# Altium schematic sheet Connect.SchDoc
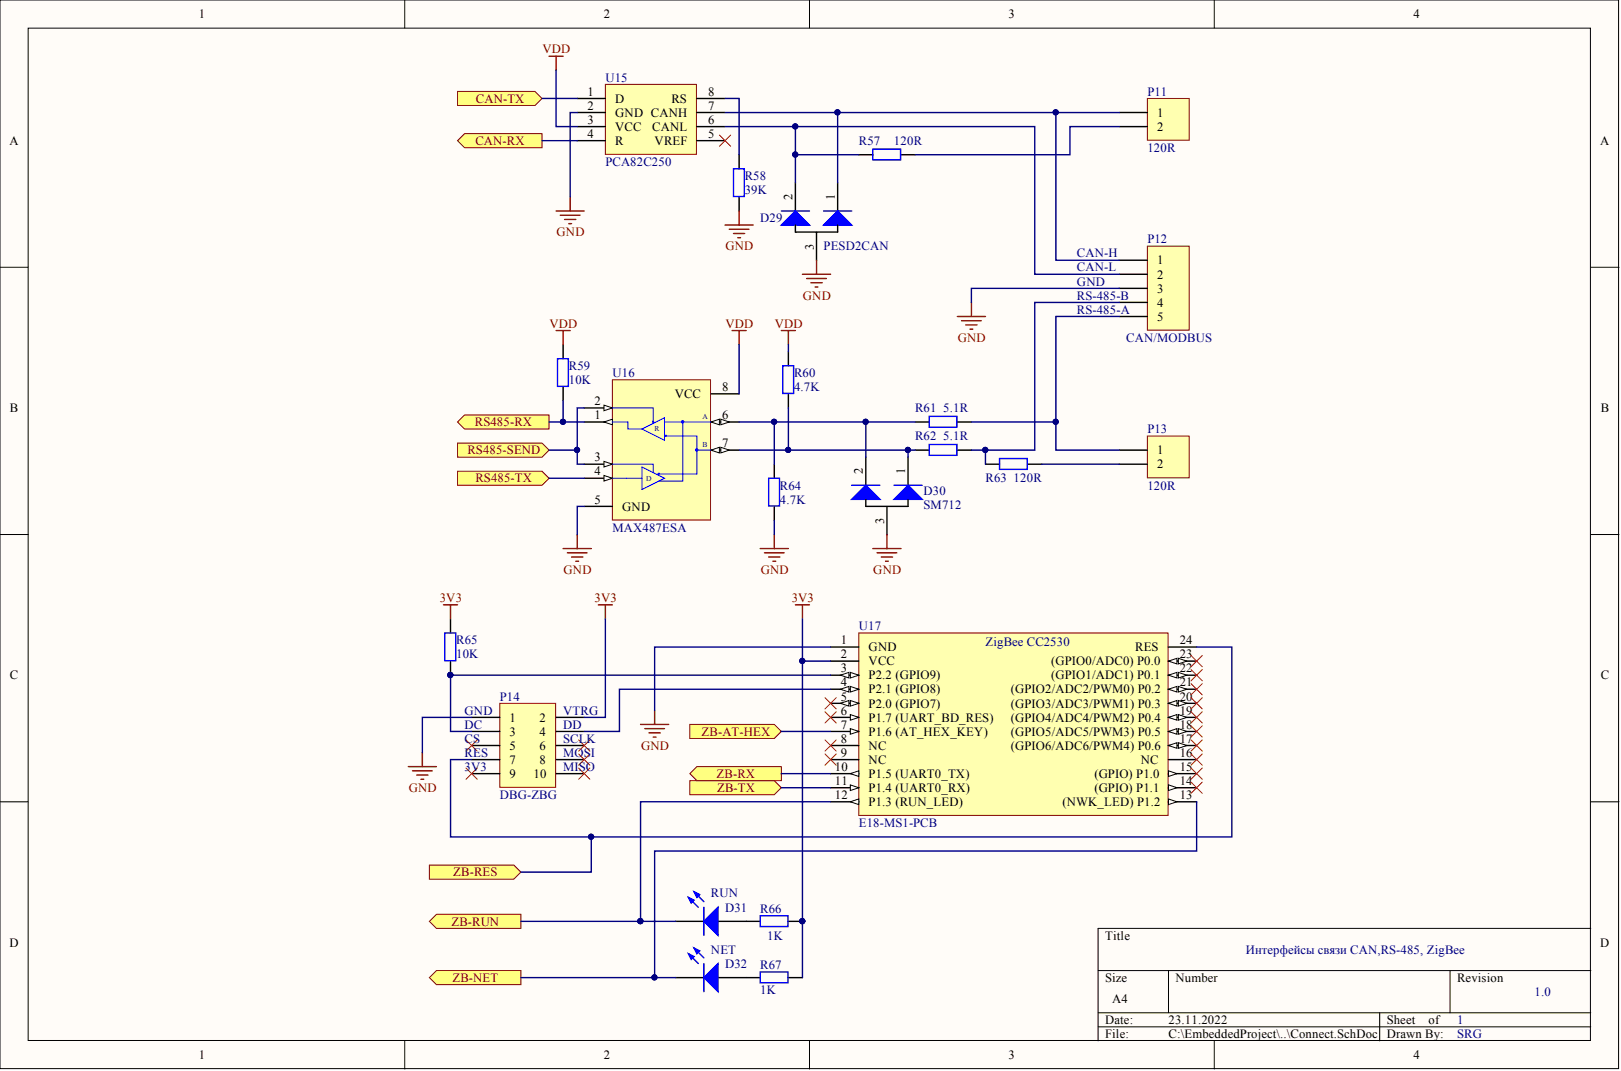
[diagram p0: Connect.SchDoc]

## Parts
D29  PESD2CAN  [SOT23-3]
D30  SM712  [SOT23-3]
D31  RUN  [LED1206 - GREEN]
D32  NET  [LED1206 - BLUE]
P11  120R  [HDR1X2]
P12  value CAN/MODBUS  [KF141V-5]  (hidden comment: CONNECT)
P13  120R  [HDR1X2]
P14  DBG-ZBG  [HDR2X5]
R57  value 120R  [R1206]  (hidden comment: Res2)
R58  value 39K  [R1206]  (hidden comment: Res2)
R59  value 10K  [R1206]  (hidden comment: Res2)
R60  value 4.7K  [R1206]  (hidden comment: Res2)
R61  value 5.1R  [R1206]  (hidden comment: Res2)
R62  value 5.1R  [R1206]  (hidden comment: Res2)
R63  value 120R  [R1206]  (hidden comment: Res2)
R64  value 4.7K  [R1206]  (hidden comment: Res2)
R65  value 10K  [R1206]  (hidden comment: Res2)
R66  value 1K  [R1206]  (hidden comment: Res2)
R67  value 1K  [R1206]  (hidden comment: Res2)
U15  value PCA82C250  [8 pin SOIC_L]  (hidden comment: CAN transiver)
U16  value MAX487ESA  [SO8_L]  (hidden comment: Low-Power, Slew-Rate-Limited RS-485/RS-422 Transceiver)
U17  E18-MS1-PCB  [E18-MS1-PCB]

## Ports
CAN-RX, CAN-TX, RS485-RX, RS485-SEND, RS485-TX, ZB-AT-HEX, ZB-NET, ZB-RES, ZB-RUN, ZB-RX, ZB-TX

## Nets
3V3: P14.2 R65.1 R66.1 R67.1 U17.2(VCC)
GND: D29.3 D30.3 P12.3 P14.1 R58.2 R64.2 U15.2(GND) U16.5(GND) U17.1(GND)
VDD: R59.1 R60.1 U15.3(VCC) U16.8(VCC)
CAN-RX: U15.4(R) ; port CAN-RX
CAN-TX: U15.1(D) ; port CAN-TX
RS485-RX: R59.2 U16.1 ; port RS485-RX
RS485-SEND: U16.2 U16.3 ; port RS485-SEND
RS485-TX: U16.4 ; port RS485-TX
ZB-AT-HEX: U17.7(P1.6_(AT_HEX_KEY)) ; port ZB-AT-HEX
ZB-NET: D32.2 U17.13((NWK_LED)_P1.2) ; port ZB-NET
ZB-RES: P14.7 U17.24(RES) ; port ZB-RES
ZB-RUN: D31.2 U17.12(P1.3_(RUN_LED)) ; port ZB-RUN
ZB-RX: U17.10(P1.5_(UART0_TX)) ; port ZB-RX
ZB-TX: U17.11(P1.4_(UART0_RX)) ; port ZB-TX
NetD29_1: D29.1 P11.1 P12.1 U15.7(CANH)
NetD29_2: D29.2 P12.2 R57.1 U15.6(CANL)
NetD30_1: D30.1 R60.2 R62.2 U16.7
NetD30_2: D30.2 R61.2 R64.1 U16.6
NetD31_1: D31.1 R66.2
NetD32_1: D32.1 R67.2
NetP11_2: P11.2 R57.2
NetP12_4: P12.4 R62.1 R63.1
NetP12_5: P12.5 P13.1 R61.1
NetP13_2: P13.2 R63.2
NetP14_3: P14.3 R65.2 U17.3(P2.2_(GPIO9))
NetP14_4: P14.4 U17.4(P2.1_(GPIO8))
NetR58_1: R58.1 U15.8(RS)

Not connected: P14 5 6 8 9 10; U15 5(VREF); U17 5(P2.0_(GPIO7)) 6(P1.7_(UART_BD_RES)) 8(NC) 9(NC) 14((GPIO)_P1.1) 15((GPIO)_P1.0) 16(NC) 17((GPIO6/ADC6/PWM4)_P0.6) 18((GPIO5/ADC5/PWM3)_P0.5) 19((GPIO4/ADC4/PWM2)_P0.4) 20((GPIO3/ADC3/PWM1)_P0.3) 21((GPIO2/ADC2/PWM0)_P0.2) 22((GPIO1/ADC1)_P0.1) 23((GPIO0/ADC0)_P0.0)

## Library data of the parts
D29 D30 = NUP2105LT1G: Transient Voltage Suppressor (TVS) Diode; Note: Pin 2 is not connected and not drawn.; Component Kind: Standard; ComponentLink1Description: Manufacturer Link; ComponentLink1URL: http://www.infineon.com/; ComponentLink2Description: Datasheet; ComponentLink2URL: http://www.infineon.com/upload/Document/cmc_upload/documents/012/5331/bas16series.pdf; DatasheetVersion: Oct-1999; LatestRevisionDate: 29-May-2009; LatestRevisionNote: IPC-7351 Footprint Added.; Library Name: Schlib1.SchLib; PackageDescription: 3-Pin SOT-23 Package 0.95 mm Pitch, 2.5 mm Lead Span; PackageReference: SOT-23; PackageVersion: Sep-2001; Published: 21-Mar-2003; Publisher: Altium Limited
  D29: Value: PESD2CAN
  D30: Value: SM712
D31 = LED1: SMD LED; Value: GREEN; Component Kind: Standard; LatestRevisionDate: 08-Jul-2005; LatestRevisionNote: Mech Layer 1 removed.; Library Name: Miscellaneous Devices.IntLib; PackageReference: LED-1; Published: 8-Jun-2000; Simulation: LED1; Publisher: Altium Limited
D32 = LED1: SMD LED; Value: BLUE; Component Kind: Standard; LatestRevisionDate: 08-Jul-2005; LatestRevisionNote: Mech Layer 1 removed.; Library Name: Miscellaneous Devices.IntLib; PackageReference: LED-1; Published: 8-Jun-2000; Simulation: LED1; Publisher: Altium Limited
P11 P13 = Header 2: Header, 2-Pin; Value: HDR1X2; value: Jumper; Component Kind: Standard; LatestRevisionDate: 17-Jul-2002; LatestRevisionNote: Re-released for DXP Platform.; Library Name: Miscellaneous Connectors.IntLib; Signal Integrity: Connector; Publisher: Altium Limited
P12 = Header 5: Header, 5-Pin; Component Kind: Standard; LatestRevisionDate: 17-Jul-2002; LatestRevisionNote: Re-released for DXP Platform.; Library Name: Miscellaneous Connectors.IntLib; PartNumber: KF141V-5; Signal Integrity: Connector; Publisher: Altium Limited
P14 = Header 5X2: Header, 5-Pin, Dual row; Value: IDC10; Component Kind: Standard; LatestRevisionDate: 17-Jul-2002; LatestRevisionNote: Re-released for DXP Platform.; Library Name: Miscellaneous Connectors.IntLib; Signal Integrity: Connector; Publisher: Altium Limited
R57-R67 = Res2: SMD 1206 Resistor Body 3.2 x 1.6 mm; Component Kind: Standard; LatestRevisionDate: 17-Jul-2002; LatestRevisionNote: Re-released for DXP Platform.; Library Name: Miscellaneous Devices.IntLib; PackageReference: AXIAL-0.4; Published: 8-Jun-2000; Signal Integrity: Res; Simulation: RESISTOR; Publisher: Altium Limited
U15 = SN65HVD230: Component Kind: Standard; Description: CAN transiver; Library Name: Schlib1.SchLib
U16 = MAX487CPA: Code_JEDEC: MS-001-AB; Component Kind: Standard; ComponentLink1Description: Manufacturer Link; ComponentLink1URL: http://www.maxim-ic.com/; ComponentLink2Description: Datasheet; ComponentLink2URL: http://pdfserv.maxim-ic.com/en/ds/MAX1487-MAX491.pdf; DatasheetVersion: Feb-1996; Description: Low-Power, Slew-Rate-Limited RS-485/RS-422 Transceiver; LatestRevisionDate: 29-May-2009; LatestRevisionNote: Component Body Added.; Library Name: Maxim Communication Transceiver.IntLib; PackageDescription: DIP, 8-Pin, Row Spacing 7.62 mm, Pitch 2.54 mm; PackageReference: PA8; PackageVersion: RevB, 21-0043; PCB3D: PA8; Published: 16-Jan-2001; Publisher: Altium Limited
U17 = E18-MS1-PCB: Zigbee IO CC2530 2,4 ГГц 2,5 МВт; Component Kind: Standard; Library Name: Schlib1.SchLib
